# Altium schematic sheet DC2018.SchDoc
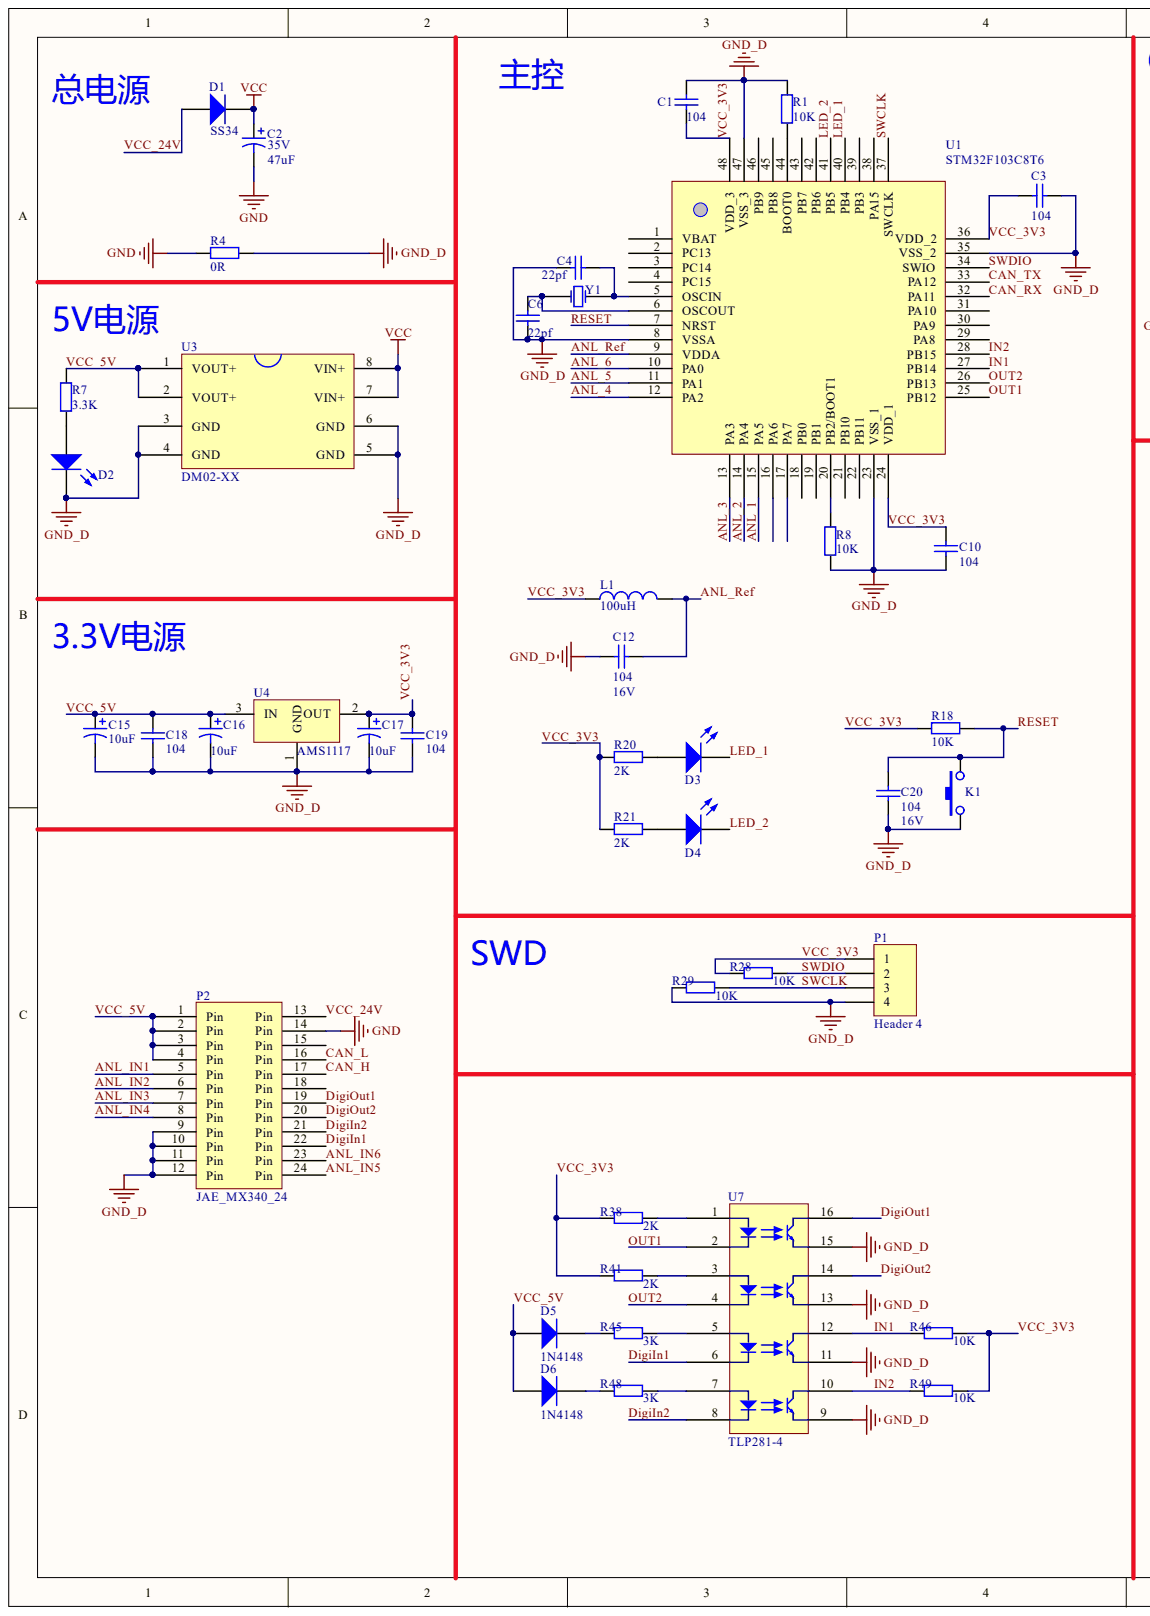
[diagram p0: DC2018.SchDoc, left]
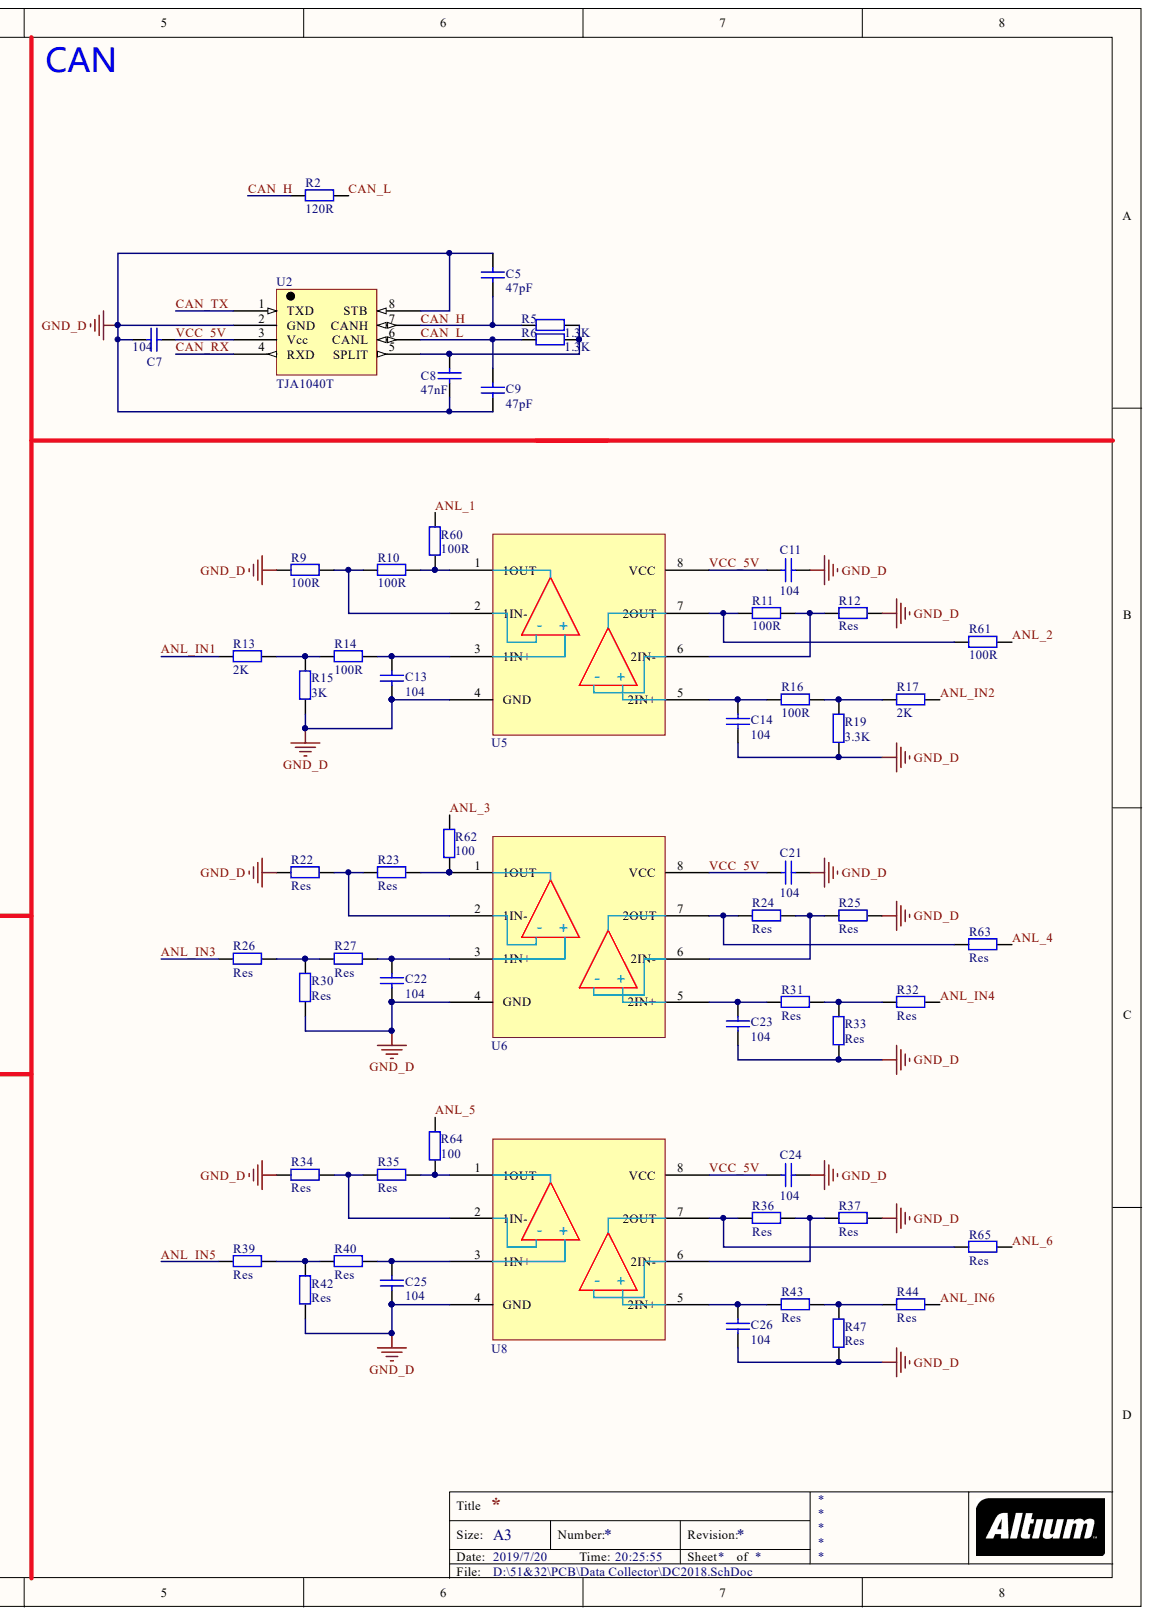
[diagram p1: DC2018.SchDoc, right]

## Parts
C1  value 104  (hidden comment: Cap)
C2  value 47uF  Pressure Voltage: 35V  (hidden comment: Cap P)
C3  value 104  (hidden comment: Cap)
C4  value 22pf  (hidden comment: Cap)
C5  value 47pF  (hidden comment: Cap)
C6  value 22pf  (hidden comment: Cap)
C7  value 104  (hidden comment: Cap)
C8  value 47nF  (hidden comment: Cap)
C9  value 47pF  (hidden comment: Cap)
C10  value 104  (hidden comment: Cap)
C11  104
C12  value 104  Pressure Voltage: 16V  (hidden comment: Cap)
C13  104
C14  104
C15  value 10uF  (hidden comment: Cap Poll)
C16  value 10uF  (hidden comment: Cap Poll)
C17  value 10uF  (hidden comment: Cap Poll)
C18  value 104  (hidden comment: Cap)
C19  value 104  (hidden comment: Cap)
C20  value 104  Pressure Voltage: 16V  (hidden comment: Cap)
C21  104
C22  104
C23  104
C24  104
C25  104
C26  104
D1  SS34
D2  LED  (value not drawn)
D3  LED
D4  LED
D5  1N4148
D6  1N4148
K1  KEY_SMT  (value not drawn)
L1  value 100uH  (hidden comment: Inductor)
P1  Header 4
P2  JAE_MX340_24
R1  value 10K  (hidden comment: Res)
R2  value 120R  (hidden comment: Res)
R4  value 0R  (hidden comment: Res)
R5  value 1.3K  (hidden comment: Res)
R6  value 1.3K  (hidden comment: Res)
R7  value 3.3K  (hidden comment: Res)
R8  value 10K  (hidden comment: Res)
R9  100R
R10  100R
R11  100R
R12  Res
R13  2K
R14  100R
R15  3K
R16  100R
R17  2K
R18  value 10K  (hidden comment: Res)
R19  3.3K
R20  value 2K  (hidden comment: Res)
R21  value 2K  (hidden comment: Res)
R22  Res
R23  Res
R24  Res
R25  Res
R26  Res
R27  Res
R28  value 10K  (hidden comment: Res)
R29  value 10K  (hidden comment: Res)
R30  Res
R31  Res
R32  Res
R33  Res
R34  Res
R35  Res
R36  Res
R37  Res
R38  value 2K  (hidden comment: Res)
R39  Res
R40  Res
R41  value 2K  (hidden comment: Res)
R42  Res
R43  Res
R44  Res
R45  value 3K  (hidden comment: Res)
R46  value 10K  (hidden comment: Res)
R47  Res
R48  value 3K  (hidden comment: Res)
R49  value 10K  (hidden comment: Res)
R60  100R
R61  100R
R62  100
R63  Res
R64  100
R65  Res
U1  STM32F103C8T6
U2  TJA1040T
U3  DM02-XX
U4  AMS1117
U5  Amplifier  (value not drawn)
U6  Amplifier  (value not drawn)
U7  TLP281-4
U8  Amplifier  (value not drawn)
Y1  XTAL_5032  (value not drawn)

## Nets
GND: C2.2 P2.14(Pin) R4.1
GND_D: C1.1 C3.2 C4.2 C5.2 C6.1 C7.1 C8.1 C9.1 C10.1 C11.2 C12.2 C13.1 C14.1 C15.2 C16.2 C17.2 C18.1 C19.1 C20.2 C21.2 C22.1 C23.1 C24.2 C25.1 C26.1 D2.2 K1.1 P1.4 P2.9(Pin) P2.10(Pin) P2.11(Pin) P2.12(Pin) R1.2 R4.2 R8.1 R9.1 R12.2 R15.1 R19.2 R22.1 R25.2 R29.1 R30.1 R33.2 R34.1 R37.2 R42.1 R47.2 U1.8(VSSA) U1.23(VSS_1) U1.35(VSS_2) U1.47(VSS_3) U2.2(GND) U2.8(STB) U3.3(GND) U3.4(GND) U3.5(GND) U3.6(GND) U4.1 U5.4(GND) U6.4(GND) U7.9 U7.11 U7.13 U7.15 U8.4(GND)
VCC: C2.1 D1.2 U3.7(VIN+) U3.8(VIN+)
ANL_1: R60.2 U1.15(PA5)
ANL_2: R61.2 U1.14(PA4)
ANL_3: R62.2 U1.13(PA3)
ANL_4: R63.2 U1.12(PA2)
ANL_5: R64.2 U1.11(PA1)
ANL_6: R65.2 U1.10(PA0)
ANL_IN1: P2.5(Pin) R13.1
ANL_IN2: P2.6(Pin) R17.2
ANL_IN3: P2.7(Pin) R26.1
ANL_IN4: P2.8(Pin) R32.2
ANL_IN5: P2.24(Pin) R39.1
ANL_IN6: P2.23(Pin) R44.2
ANL_REF: C12.1 L1.2 U1.9(VDDA)
CAN_H: C5.1 P2.17(Pin) R2.2 R5.1 U2.7(CANH)
CAN_L: C9.2 P2.16(Pin) R2.1 R6.1 U2.6(CANL)
CAN_RX: U1.32(PA11) U2.4(RXD)
CAN_TX: U1.33(PA12) U2.1(TXD)
DIGIIN1: P2.22(Pin) U7.6
DIGIIN2: P2.21(Pin) U7.8
DIGIOUT1: P2.19(Pin) U7.16
DIGIOUT2: P2.20(Pin) U7.14
IN1: R46.1 U1.27(PB14) U7.12
IN2: R49.1 U1.28(PB15) U7.10
LED_1: D3.2 U1.40(PB4)
LED_2: D4.2 U1.41(PB5)
OUT1: U1.25(PB12) U7.2
OUT2: U1.26(PB13) U7.4
RESET: C20.1 K1.2 R18.2 U1.7(NRST)
SWCLK: P1.3 R29.2 U1.37(SWCLK)
SWDIO: P1.2 R28.2 U1.34(SWIO)
VCC_3V3: C1.2 C3.1 C10.2 C17.1 C19.2 L1.1 P1.1 R18.1 R20.1 R21.1 R28.1 R38.1 R41.1 R46.2 R49.2 U1.24(VDD_1) U1.36(VDD_2) U1.48(VDD_3) U4.2
VCC_5V: C7.2 C11.1 C15.1 C16.1 C18.2 C21.1 C24.1 D5.1 D6.1 P2.1(Pin) P2.2(Pin) P2.3(Pin) P2.4(Pin) R7.2 U2.3(Vcc) U3.1(VOUT+) U3.2(VOUT+) U4.3 U5.8(VCC) U6.8(VCC) U8.8(VCC)
VCC_24V: D1.1 P2.13(Pin)
NetC4_1: C4.1 U1.5(OSCIN) Y1.2
NetC6_2: C6.2 U1.6(OSCOUT) Y1.1
NetC8_2: C8.2 R5.2 R6.2 U2.5(SPLIT)
NetC13_2: C13.2 R14.2 U5.3(1IN+)
NetC14_2: C14.2 R16.1 U5.5(2IN+)
NetC22_2: C22.2 R27.2 U6.3(1IN+)
NetC23_2: C23.2 R31.1 U6.5(2IN+)
NetC25_2: C25.2 R40.2 U8.3(1IN+)
NetC26_2: C26.2 R43.1 U8.5(2IN+)
NetD2_1: D2.1 R7.1
NetD3_1: D3.1 R20.2
NetD4_1: D4.1 R21.2
NetD5_2: D5.2 R45.1
NetD6_2: D6.2 R48.1
NetR1_1: R1.1 U1.44(BOOT0)
NetR8_2: R8.2 U1.20(PB2/BOOT1)
NetR9_2: R9.2 R10.1 U5.2(1IN-)
NetR10_2: R10.2 R60.1 U5.1(1OUT)
NetR11_1: R11.1 R61.1 U5.7(2OUT)
NetR11_2: R11.2 R12.1 U5.6(2IN-)
NetR13_2: R13.2 R14.1 R15.2
NetR16_2: R16.2 R17.1 R19.1
NetR22_2: R22.2 R23.1 U6.2(1IN-)
NetR23_2: R23.2 R62.1 U6.1(1OUT)
NetR24_1: R24.1 R63.1 U6.7(2OUT)
NetR24_2: R24.2 R25.1 U6.6(2IN-)
NetR26_2: R26.2 R27.1 R30.2
NetR31_2: R31.2 R32.1 R33.1
NetR34_2: R34.2 R35.1 U8.2(1IN-)
NetR35_2: R35.2 R64.1 U8.1(1OUT)
NetR36_1: R36.1 R65.1 U8.7(2OUT)
NetR36_2: R36.2 R37.1 U8.6(2IN-)
NetR38_2: R38.2 U7.1
NetR39_2: R39.2 R40.1 R42.2
NetR41_2: R41.2 U7.3
NetR43_2: R43.2 R44.1 R47.1
NetR45_2: R45.2 U7.5
NetR48_2: R48.2 U7.7

Not connected: P2 15(Pin) 18(Pin); U1 1(VBAT) 2(PC13) 3(PC14) 4(PC15) 16(PA6) 17(PA7) 18(PB0) 19(PB1) 21(PB10) 22(PB11) 29(PA8) 30(PA9) 31(PA10) 38(PA15) 39(PB3) 42(PB6) 43(PB7) 45(PB8) 46(PB9)

## Library data of the parts
C1 C3-C10 C18 C19 = (no symbol name): 原理图绘制者: 刘永钦; 原理图何处使用过: 2014年飞思卡尔底板; 原理图审核人1: 徐鹏飞; 封装0805何处使用: 2015年航行器遥控器; 原理图审核人2: 朱觉文; 封装0603何处使用过: 在2401转接板上使用; Pressure Voltage: 16V; 原理图提供者: 刘永钦; 封装0805绘制者: 刘永钦; 封装0805提供者: 刘永钦; 封装0805审核人1: 徐鹏飞; 封装0805审核人2: 朱觉文; 封装0603绘制者: 刘永钦; 封装0603提供者: 刘永钦; 封装0603审核人1: 朱觉文; 封装0603审核人2: 徐鹏飞
C12 C20 = (no symbol name): 原理图绘制者: 刘永钦; 原理图何处使用过: 2014年飞思卡尔底板; 原理图审核人1: 徐鹏飞; 封装0805何处使用: 2015年航行器遥控器; 原理图审核人2: 朱觉文; 封装0603何处使用过: 在2401转接板上使用; 原理图提供者: 刘永钦; 封装0805绘制者: 刘永钦; 封装0805提供者: 刘永钦; 封装0805审核人1: 徐鹏飞; 封装0805审核人2: 朱觉文; 封装0603绘制者: 刘永钦; 封装0603提供者: 刘永钦; 封装0603审核人1: 朱觉文; 封装0603审核人2: 徐鹏飞
C15-C17 = (no symbol name): 原理图绘制者: 刘永钦; 原理图何处使用过: 2014年飞思卡尔底板; 原理图审核人1: 徐鹏飞; 封装CAP 钽 7343 E何处使用: 2014年飞思卡尔驱动板; 封装cap贴片铝电解质470uF 16V绘制者: 李泽; 封装cap贴片铝电解质470uF 16V何处使用: 2015年飞思卡尔主板; 封装Cap 3528B绘制者: 陈汉诚; 封装Cap 3528B使用过: 2015年飞思卡尔驱动板; 封装Cap直插 10uF 50V: 10级718学长; 封装Cap直插 10uF 50V使用过: 2012年XS128学习板的主板; 封装Cap 3528B审核人2: 朱觉文; Pressure Voltage: 16V; 原理图提供者: 刘永钦; 封装CAP 钽 7343 E绘制者: 刘永钦; 封装CAP 钽 7343 E提供者: 刘永钦; 封装CAP 钽 7343 E审核人1: 徐鹏飞; 封装cap贴片铝电解质470uF 16V提供者: 刘永钦; 封装cap贴片铝电解质470uF 16V审核人1: 徐鹏飞; 封装Cap 3528B提供者: 刘永钦; 封装Cap 3528B审核人1: 徐鹏飞; 封装Cap直插 10uF 50V提供者: 刘永钦; 封装Cap直插 10uF 50V审核人1: 徐鹏飞; 封装Cap直插 10uF 50V审核人2: 朱觉文; 封装cap贴片铝电解质470uF 16V审核人2: 朱觉文; 封装CAP 钽 7343 E审核人2: 朱觉文; 原理图审核人2: 朱觉文
D1 = (no symbol name): 原理图绘制者: 赵某某; 原理图提供者: 周某某; 原理图何处使用过: 2014年飞思卡尔运放板; 原理图审核人: 李某某; 封装DIO-214绘制者: 刘永钦; 封装DIO-214审核人1: 徐鹏飞; 封装DIO-214审核人2: 朱觉文; 封装DIO-214何处使用过: 2014年飞思卡尔运放板; 封装DIO-214提供者: 刘永钦
D2-D4 = (no symbol name): 原理图绘制者: 刘永钦; 原理图何处使用过: 2014年飞思卡尔底板; 原理图审核人1: 徐鹏飞; Color: 蓝色; 封装1210LED何处使用过: 2014年的一个小板子; 封装LED_3mm何处使用过: 2014年变频器面板; 封装LED_0805使用过: 2015年飞思卡尔底板; 原理图审核人2: 朱觉文; 封装LED0603何处使用过: 2401转接板; 原理图提供者: 刘永钦; 封装1210LED绘制者: 刘永钦; 封装1210LED提供者: 刘永钦; 封装1210LED审核人1: 徐鹏飞; 封装LED_3mm绘制者: 刘永钦; 封装LED_3mm提供者: 刘永钦; 封装LED_0805绘制者: 刘永钦; 封装LED_0805提供者: 刘永钦; 封装LED_0805审核人1: 徐鹏飞; 封装1210LED审核人2: 朱觉文; 封装LED_0805审核人2: 朱觉文; 封装LED_3mm审核者2: 朱觉文; 封装LED0603绘制者: 刘永钦; 封装LED0603审核人2: 朱觉文; 封装LED0603提供者: 刘永钦; 封装LED0603审核人1: 徐鹏飞
L1 = (no symbol name): 原理图绘制者: 刘永钦; 原理图何处使用过: 2014年飞思卡尔底板; 原理图审核人1: 徐鹏飞; 封装0805_Inductor绘制者: 未知; 封装0805_Inductor使用过: 2015年飞思卡尔底板; 原理图审核人2: 朱觉文; 原理图提供者: 刘永钦; 封装0805_Inductor提供者: 刘永钦; 封装0805_Inductor审核人1: 徐鹏飞; 封装0805_Inductor审核人2: 朱觉文
R1 R2 R4-R8 R18 R20 R21 R28 R29 R38 R41 R45 R46 R48 R49 = (no symbol name): 原理图绘制者: 刘永钦; 原理图何处使用过: 2014年飞思卡尔底板; 原理图审核人1: 徐鹏飞; 封装0805何处使用过: 2015年航行器遥控器; 原理图审核人2: 朱觉文; 封装2512-RESC6332X06N何处使用过: 在无刷直流电机驱动板上使用过; 封装0603何处使用过: 在2401转接板上使用; 原理图提供者: 刘永钦; 封装0805绘制者: 刘永钦; 封装0805提供者: 刘永钦; 封装0805审核人1: 徐鹏飞; 封装0805审核人2: 朱觉文; 封装0603提供者: 刘永钦; 封装0603审核人2: 朱觉文; 封装0603审核人1: 徐鹏飞; 封装2512-RESC6332X06N审核人2: 朱觉文; 封装2512-RESC6332X06N提供者: 刘永钦; 封装2512-RESC6332X06N审核人1: 徐鹏飞; 封装0603绘制者: 刘永钦; 封装2512-RESC6332X06N绘制者: 刘永钦
U4 = (no symbol name): 原理图绘制者: 刘永钦; 原理图何处使用过: 2014年飞思卡尔底板; 原理图审核人1: 徐鹏飞; 原理图审核人2: 朱觉文; 封装AMS1117何处使用: 2014年飞思卡尔底板; 原理图提供者: 刘永钦; 封装AMS1117绘制者: 刘永钦; 封装AMS1117提供者: 刘永钦; 封装AMS1117审核人1: 徐鹏飞; 封装AMS1117审核人2: 朱觉文
C2 C11 C13 C14 C21-C26 D5 D6 K1 P1 P2 R9-R17 R19 R22-R27 R30-R37 R39 R40 R42-R44 R47 R60-R65 U1-U3 U5-U8 Y1 = (no symbol name)
  P1: LatestRevisionDate: 17-Jul-2002; LatestRevisionNote: Re-released for DXP Platform.; Publisher: Altium Limited
